# Altium schematic sheet TS7_FPC_UI_Top.SchDoc(TS7_FPC_UI_Top)
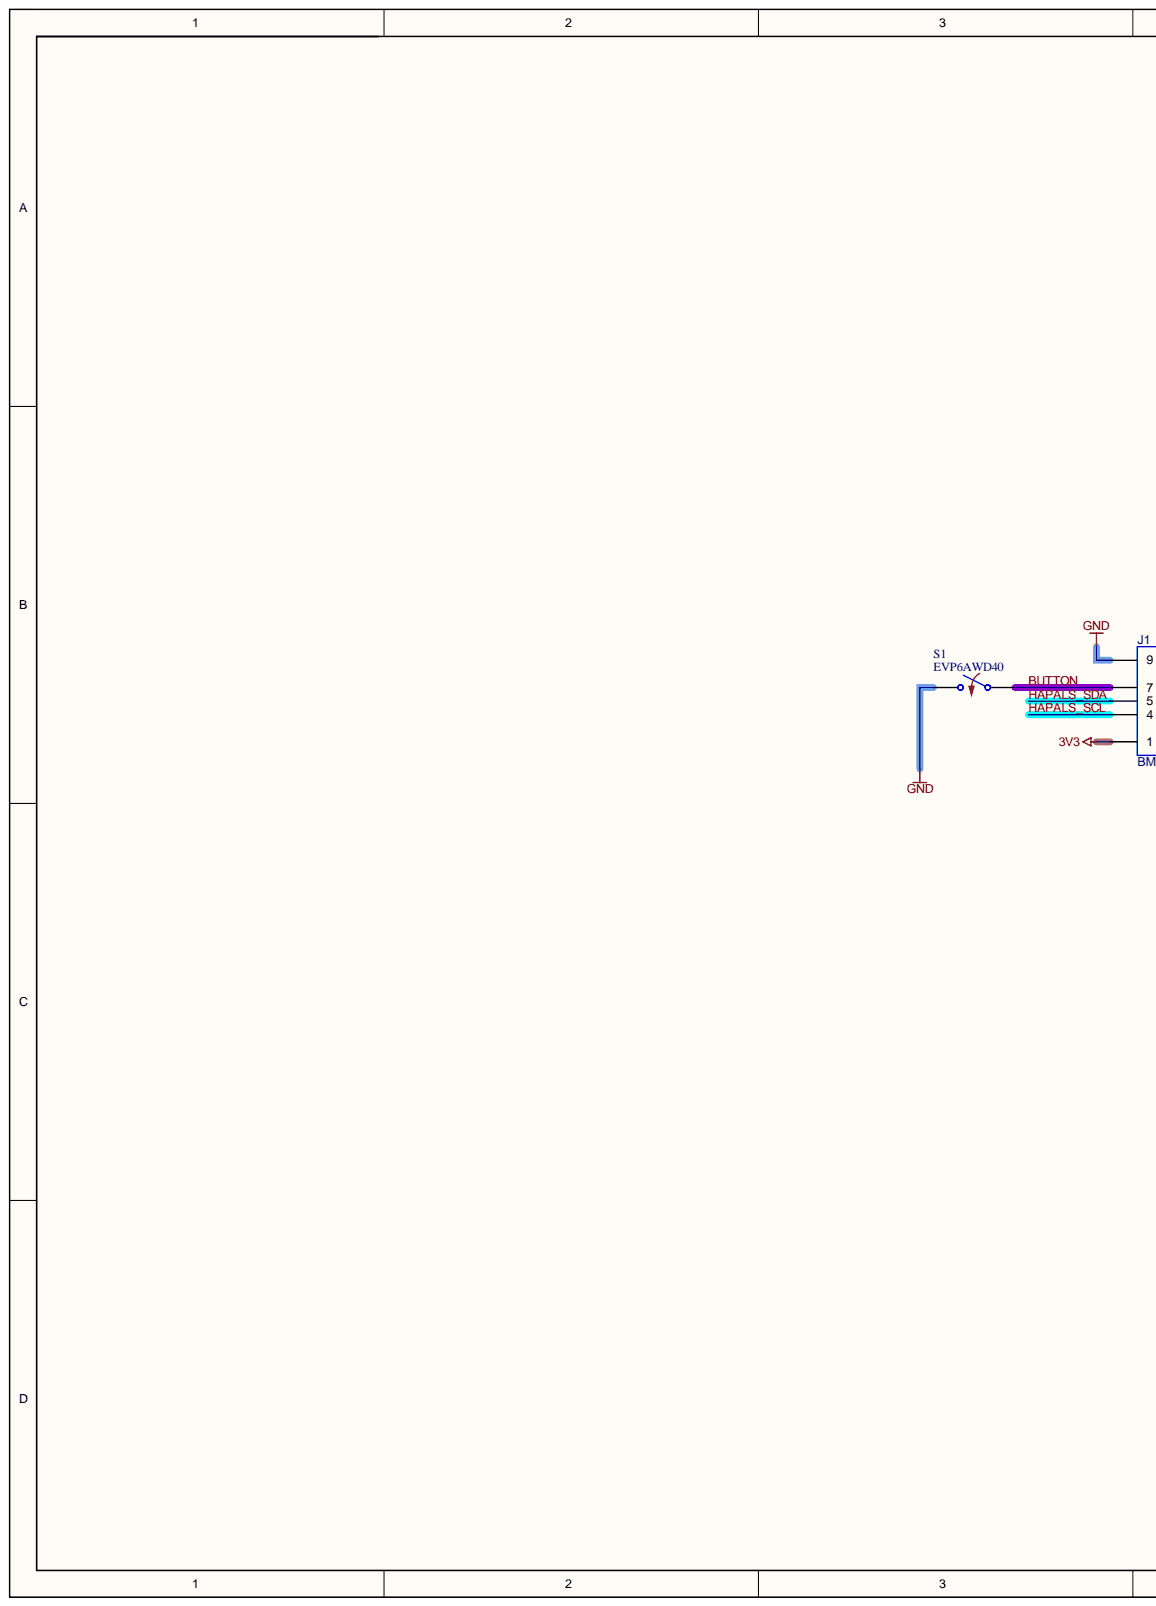
[diagram p0: TS7_FPC_UI_Top.SchDoc, left]
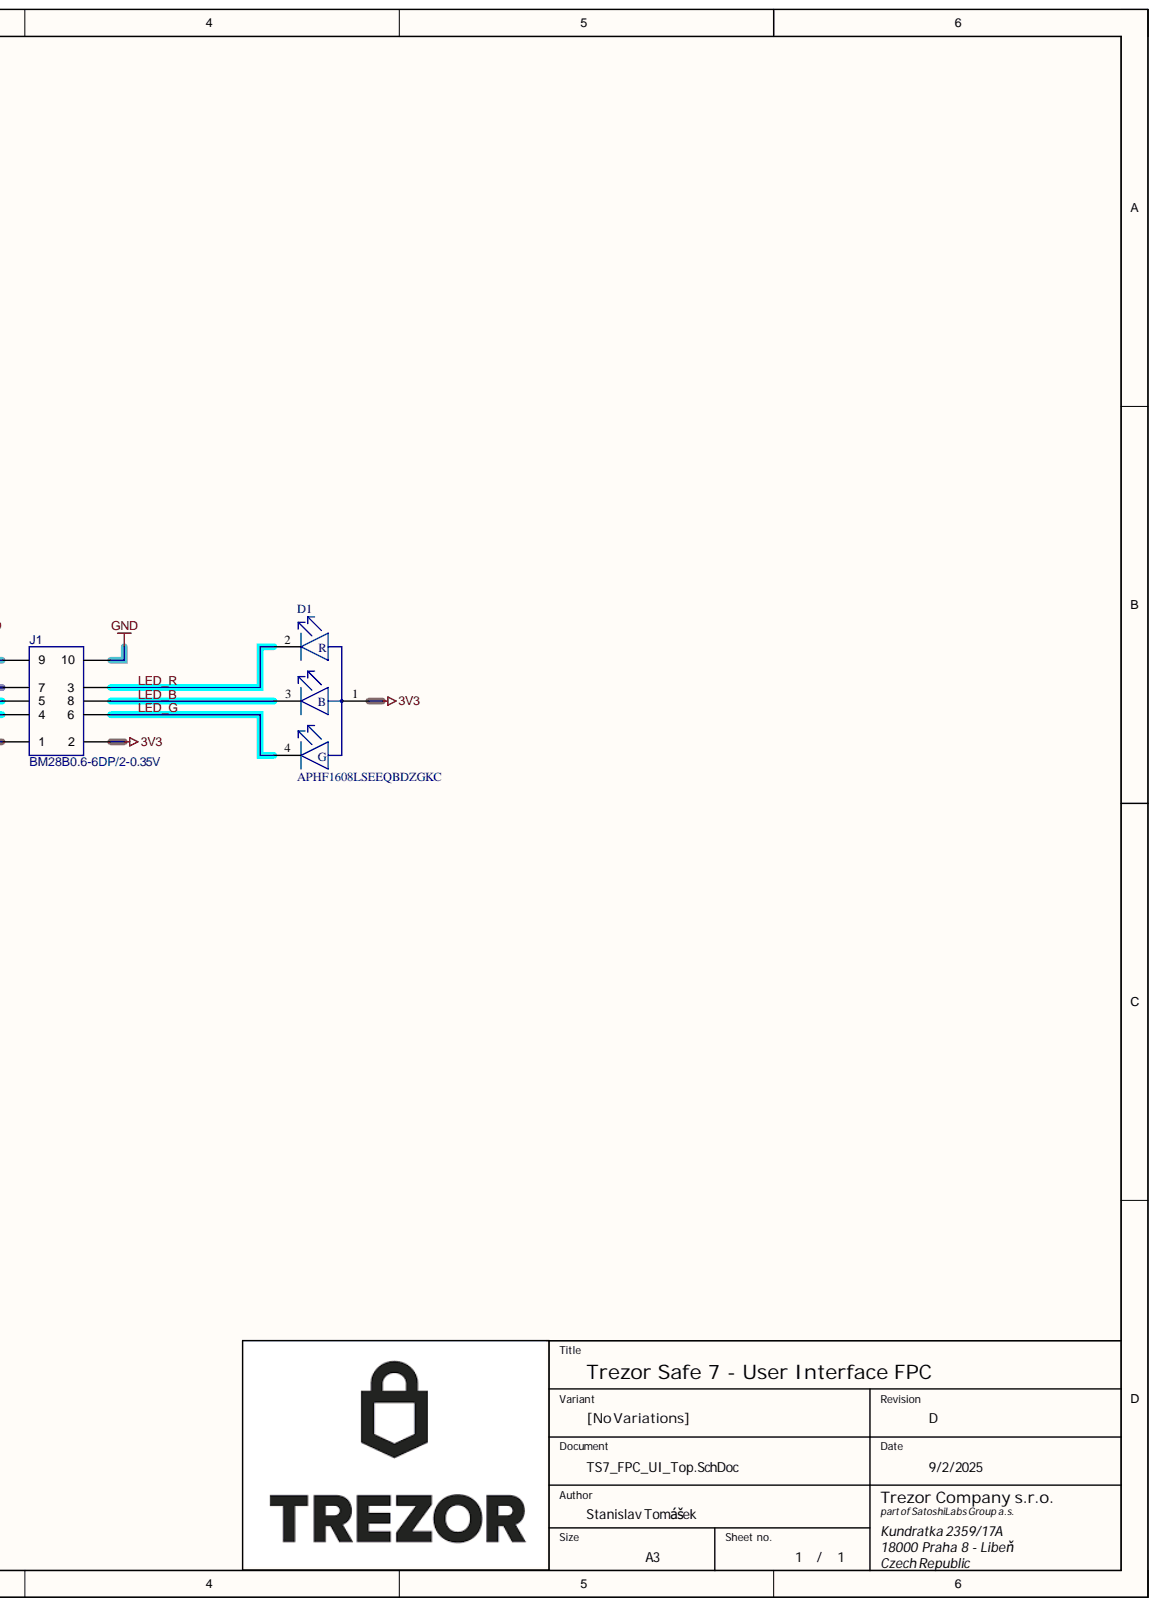
[diagram p1: TS7_FPC_UI_Top.SchDoc, right]

## Parts
D1  APHF1608LSEEQBDZGKC  [FP-APHF1608LSEEQBDZGKC-MFG]
J1  BM28B0.6-6DP/2-0.35V  [BM28B0.6-6DP_2-0.35V-Footprint-1]
S1  EVP6AWD40  [EVP6A]

## Nets
3V3: D1.1(B) J1.1 J1.2
GND: J1.9 J1.10 S1.2
BUTTON: J1.7 S1.1
HAPALS_SCL: J1.4
HAPALS_SDA: J1.5
LED_B: D1.3 J1.8
LED_G: D1.4(G) J1.6
LED_R: D1.2(R) J1.3

## Library data of the parts
D1 = CMP-44529-000066-1: LED RGB CLEAR 4SMD; Color: Blue; Dominant Wavelength: 465nm; Element Configuration: Common Anode; Forward Voltage: 2.65V; Lens Color: Colorless; Lens Size: 0.8mm; Lens Style: Flat; Luminous Intensity: 10mcd; Test Current: 2mA; Viewing Angle: 140°; Case/Package: SMD/SMT; Component Kind: Standard; Height: 0.6mm; Length: 1.6mm; Lens Transparency: Clear; Library Name: Altium Content Vault; Mount: Surface Mount; Packaging: Tape and Reel; RoHS Compliant: Yes; Width: 0.8mm
J1 = CMP-119-000001-2: BM28 Series 0.35mm Pitch, 0.6mm Stacking Height, FPC-to-Board Connectors Supporting Up to 5A; MFR: Hirose; MPN: BM28B0.6-6DP/2-0.35V(51); Component Kind: Standard; Library Name: Trezor Company s.r.o.
S1 = CMP-011-00000-4: Operation switches for portable electronic equipment (Mobile phone, Portable audio). Low profile (0.6mm) and Small size (3.0 x 2.0mm). IP67; MFR: Panasonic Industry; Component Kind: Standard; MPN: EVP6AWD40; Library Name: Trezor Company s.r.o.
